# Altium schematic sheet Inverter.SchDoc
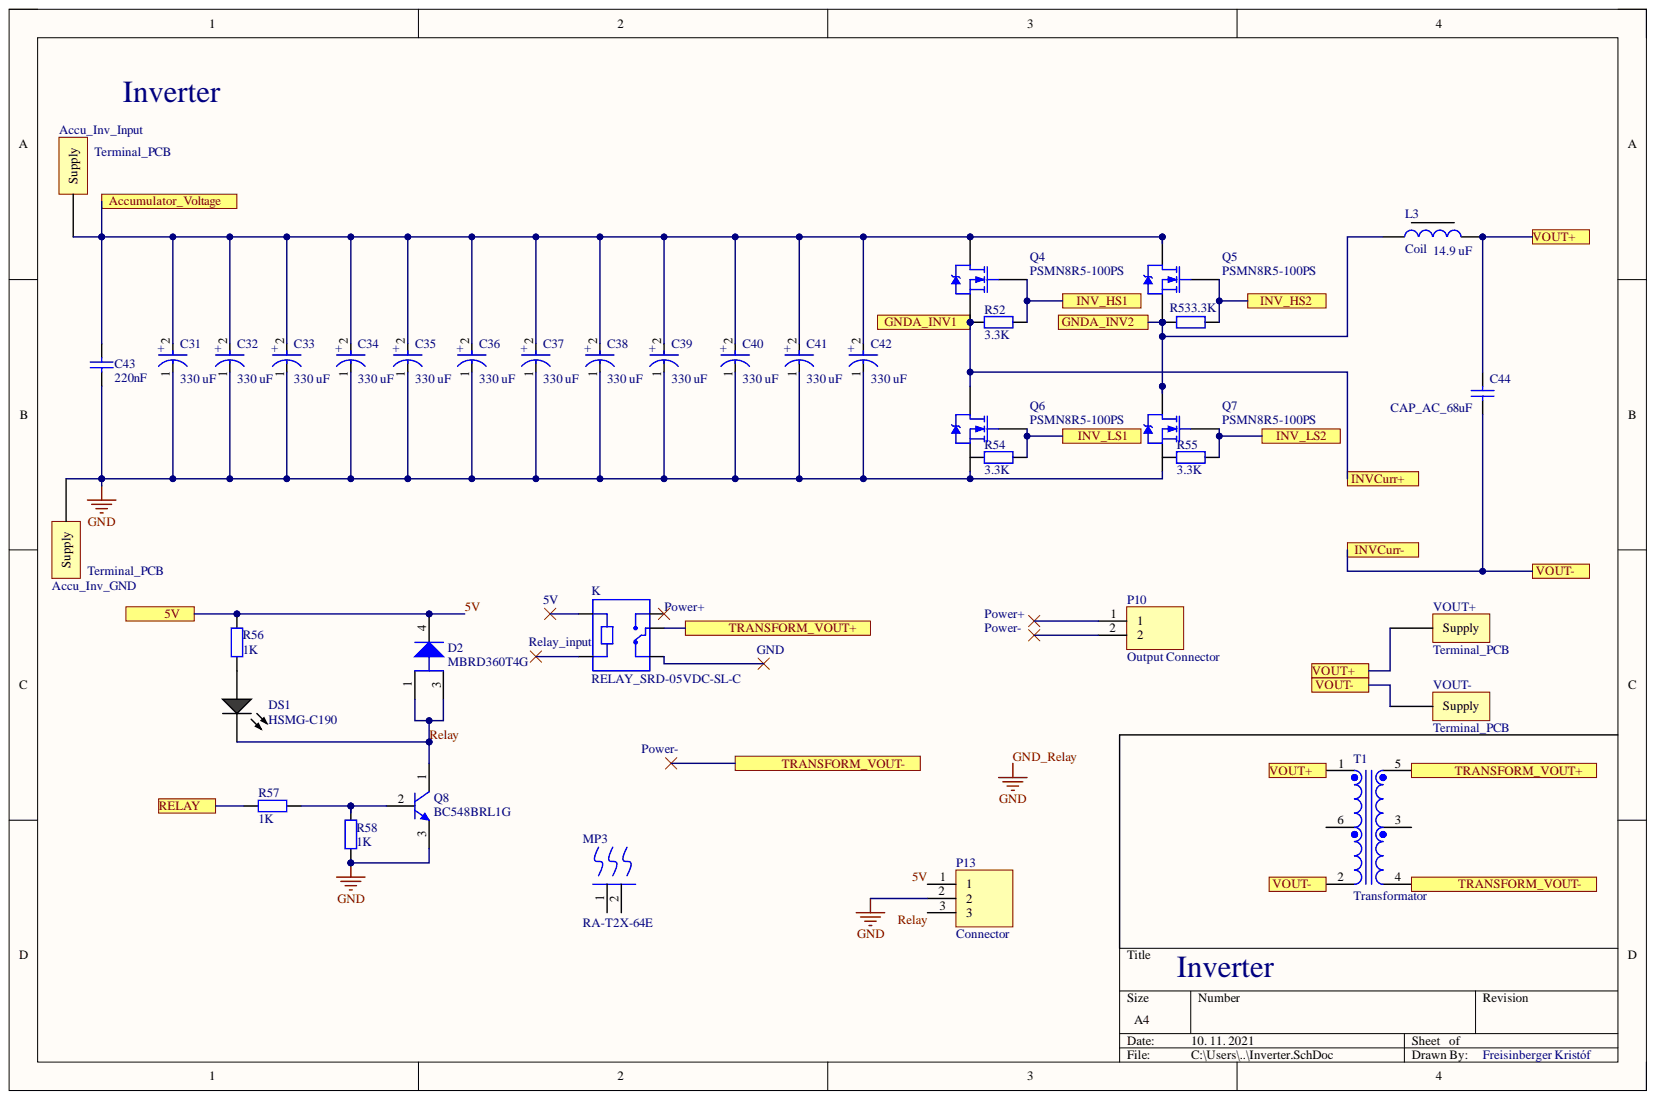
[diagram p0: Inverter.SchDoc]

## Parts
Accu_Inv_GND  Terminal_PCB  [Terminal_PCB_7798]
Accu_Inv_Input  Terminal_PCB  [Terminal_PCB_7798]
C31  330 uF  [FP-LXW18X25-MFG]
C32  330 uF  [FP-LXW18X25-MFG]
C33  330 uF  [FP-LXW18X25-MFG]
C34  330 uF  [FP-LXW18X25-MFG]
C35  330 uF  [FP-LXW18X25-MFG]
C36  330 uF  [FP-LXW18X25-MFG]
C37  330 uF  [FP-LXW18X25-MFG]
C38  330 uF  [FP-LXW18X25-MFG]
C39  330 uF  [FP-LXW18X25-MFG]
C40  330 uF  [FP-LXW18X25-MFG]
C41  330 uF  [FP-LXW18X25-MFG]
C42  330 uF  [FP-LXW18X25-MFG]
C43  220nF  [FP_0805_CAP_085]
C44  CAP_AC_68uF  [Capacitor_AC_68_uF]
D2  MBRD360T4G  [ONSC-DPAK-3-369C-01_V]
DS1  HSMG-C190  [AVAG-HSMX-C190_V]
K  RELAY_SRD-05VDC-SL-C  [FP_CONN3_2MM_90]
L3  Coil  value 14.9 uF  [Tekercs_E65_FERRITE]
MP3  RA-T2X-64E  [FP-RA-T2X-64E-MFG]
P10  Output Connector  [FP_CONN2_TAP_254MM]
P13  Connector  [FP_CONN3_TAP_254MM]
Q4  PSMN8R5-100PS  [FP-TO-220AB-MFG]
Q5  PSMN8R5-100PS  [FP-TO-220AB-MFG]
Q6  PSMN8R5-100PS  [FP-TO-220AB-MFG]
Q7  PSMN8R5-100PS  [FP-TO-220AB-MFG]
Q8  BC548BRL1G  [ONSC-TO-92-3-29-11_AM_BL]
R52  3.3K  [FP_0603_RES]
R53  3.3K  [FP_0603_RES]
R54  3.3K  [FP_0603_RES]
R55  3.3K  [FP_0603_RES]
R56  1K  [FP_0603_RES]
R57  1K  [FP_0603_RES]
R58  1K  [FP_0603_RES]
T1  Transformator  [TDK-ALT3232_V]
VOUT+  Terminal_PCB  [Terminal_PCB_7798]
VOUT-  Terminal_PCB  [Terminal_PCB_7798]

## Ports
5V, Accumulator_Voltage, GNDA_INV1, GNDA_INV2, INV_HS1, INV_HS2, INV_LS1, INV_LS2, INVCurr+, INVCurr-, RELAY, TRANSFORM_VOUT+, TRANSFORM_VOUT-, VOUT+, VOUT-

## Nets
5V: D2.4 P13.1 R56.2 ; port 5V
Accumulator_Voltage: Accu_Inv_Input.1 C31.2 C32.2 C33.2 C34.2 C35.2 C36.2 C37.2 C38.2 C39.2 C40.2 C41.2 C42.2 C43.2 Q4.2 Q5.2 ; port Accumulator_Voltage
GND_Relay: Accu_Inv_GND.1 C31.1 C32.1 C33.1 C34.1 C35.1 C36.1 C37.1 C38.1 C39.1 C40.1 C41.1 C42.1 C43.1 P13.2 Q6.3 Q7.3 Q8.3 R58.1
GNDA_INV1: Q4.3 Q6.2 R52.1 ; port GNDA_INV1
GNDA_INV2: L3.1 Q5.3 Q7.2 R53.1 ; port GNDA_INV2
INV_HS1: Q4.1 R52.2 ; port INV_HS1
INV_HS2: Q5.1 R53.2 ; port INV_HS2
INV_LS1: Q6.1 R54.2 ; port INV_LS1
INV_LS2: Q7.1 R55.2 ; port INV_LS2
RELAY: R57.1 ; port RELAY
Relay: D2.1 D2.3 DS1.2 P13.3 Q8.1
NetC44_1: C44.1 T1.2 VOUT-.1
NetC44_2: C44.2 L3.2 T1.1 VOUT+.1
NetDS1_1: DS1.1 R56.1
NetK_COM: K.COM T1.5
NetQ8_2: Q8.2 R57.2 R58.2
NetT1_4: T1.4

Not connected: K A1 A2 NC NO; MP3 1 2; P10 1 2; R54 1; R55 1; T1 3 6

## Library data of the parts
C31-C42 = CMP-35376-000008-1: CAP ALUM 47UF 20% 500V THRUHOLE  500LXW47MEFR18X25; Component Kind: Standard; Library Name: Altium Content Vault
C43 = CAP: Capacitor; Component Kind: Standard; Value: 220nF; Library Name: Napelem_Inverter.SchLib
C44 = CAP_AC_68uF: Cap Film 68uF 100V PET 10% (31.5 X 22 X 36.5mm) Radial 27.5mm 125C Bulk; Manufacturer: TDK EPCOS; Manufacturer Part Number: B32524Q1686K000; Dielectric Material: Polyester; Lead Diameter: 0.8mm; Capacitance: 68uF; Case/Package: Radial; Category: Film Capacitors; Component Kind: Standard; ComponentLink1Description: http://www.farnell.com/datasheets/1955213.pdf?src-supplier=Farnell; ComponentLink1URL: http://www.farnell.com/datasheets/1955213.pdf?src-supplier=Farnell; ComponentLink2Description: http://components.arrow.com/part/dataview/0323_01924821?src-supplier=Arrow+Europe; ComponentLink2URL: http://components.arrow.com/part/dataview/0323_01924821?src-supplier=Arrow+Europe; ComponentLink3Description: https://www.tdk-electronics.tdk.com/inf/20/20/db/fc_2009/B32520_529.pdf?src-supplier=Digi-Key; ComponentLink3URL: https://www.tdk-electronics.tdk.com/inf/20/20/db/fc_2009/B32520_529.pdf?src-supplier=Digi-Key; ComponentLink4Description: http://www.farnell.com/datasheets/1955213.pdf?src-supplier=Element14; ComponentLink4URL: http://www.farnell.com/datasheets/1955213.pdf?src-supplier=Element14; Depth: 22mm; Dielectric: PET; Lead Pitch: 27.5mm; Length: 31.5mm; Max Operating Temperature: 125°C; Min Operating Temperature: -55°C; Packaging: Bulk; Pricing 1: 1=16,57000, 10=15,74200, 50=13,25680, 100=12,42830, 500=11,01972, 1000=10,35688 (USD); REACH SVHC: No SVHC; RoHS: Yes; RoHS Compliant: Yes; Stock 1: 15; Supplier 1: Digi-Key; Tolerance: 10%; Voltage Rating: 100V; Voltage Rating (DC): 100V; Library Name: Napelem_Inverter.SchLib
D2 = CMP-1055-00192-1: SWITCHMODE(TM) Power Rectifier, 3-Pin DPAK, Pb-Free, Tape and Reel; Manufacturer: On Semiconductor; Configuration: Single; IFSM Max (A): 75; Component Kind: Standard; ComponentLink1Description: Manufacturer URL; ComponentLink1URL: http://www.onsemi.com/; ComponentLink2Description: Datasheet; ComponentLink2URL: http://www.onsemi.com/pub_link/Collateral/MBRD320-D.PDF; ComponentLink3Description: Package Specification; ComponentLink3URL: http://www.onsemi.cn/pub_link/Collateral/369C.PDF; DatasheetVersion: Rev. 8; Library Name: Altium Content Vault; Mounting Technology: Surface Mount; PackageDescription: 3-Pin Flange Mount, Body 6.54 x 6.095 mm, Pitch 2.29 mm; PackageReference: DPAK-3-369C-01; PackageVersion: Rev. E, 02/2014; Packing: Tape and Reel; PartNumber: MBRD360T4G; RoHS: TRUE
DS1 = LED_G_CMP-1744-00002-1_1: Chip LED, Green, 2.2 V, -40 to 85 degC, 2-Pin SMD, RoHS, Tape and Reel; Access Time: 3500 ns; Case\Package: SOIC; Clock Frequency: 100 KHz; Density: 32 kb; Frequency: 400 KHz; Interface: Serial; Max Supply Voltage: 5.5 V; Min Supply Voltage: 1.7 V; Nominal Supply Current: 2 mA; Component Kind: Standard; ComponentLink1Description: Manufacturer URL; ComponentLink1URL: http://www.avagotech.com/; ComponentLink2Description: Datasheet; ComponentLink2URL: http://docs.avagotech.com/docs/AV02-0551EN; ComponentLink3Description: Package Specification; ComponentLink3URL: http://www.fairchildsemi.com/dwg/MA/MA03D.pdf; DatasheetVersion: Mar-12; Height: 1.5 mm; Length: 5 mm; Max Clock Frequency: 100 KHz; Max Operating Temperature: 85 degC; Min Operating Temperature: -40 degC; Mount: Surface Mount; Package Quantity: 3000; PackageDescription: 2-Pin Surface Mount Device, Body 1.6 x 0.8 mm, Height 0.9 mm; PackageReference: HSMX-C190; Packaging: Tape and Reel; Pins: 8; Radiation Hardening: No; REACH SVHC: No SVHC; RoHSCompliant: true; Width: 4 mm; Library Name: Napelem_Inverter.SchLib
K = RELAY_SRD-05VDC-SL-C: 5V Trigger Relay Module For Arduino And Raspberry Pi 5V Trigger Relay Module For Arduino And Raspberry Pi; MF: Songle Relay; Availability: Unavailable; Component Kind: Standard; MP: SRD-05VDC-SL-C; Package: None; Price: None; SnapEDA_Link: https://www.snapeda.com/parts/SRD-05VDC-SL-C/Songle%20Relay/view-part/1029484/?ref=snap; Library Name: Napelem_Inverter.SchLib
L3 = Tekercs: 14.9 uH inductor; Category: Inductor; Component Kind: Standard; Library Name: Napelem_Inverter.SchLib
MP3 = CMP-33535-000005-1: HEATSINK TO-218,TO-220,TO-247; Natural Thermal Resistance: 3.1°C/W; Shape: Fins; Thermal Resistance @ Forced Air Flow (100 LFM): 1°C/W; Case/Package: TO-220; Color: Black; Component Kind: Standard; Height: 63.5mm; Height Off Base: 0.984inch; Length: 42mm; Library Name: Altium Content Vault; Material: Aluminium; Mount: Bolt; Package Quantity: 10; Packaging: Bulk; Type: Passive; Weight: 63g; Width: 25mm
P10 = Connector_2pin: 2pin connector; Component Kind: Standard; Library Name: Napelem_Inverter.SchLib
P13 = Connector_3pin: 3pin connector; Component Kind: Standard; Library Name: Napelem_Inverter.SchLib
Q4-Q7 = NMOS-2: N-channel 100 V 8.5 mΩ standard level MOSFET in TO220; Note: Pin 2 is electrically connected to pin 4.; Component Kind: Standard; ComponentLink1Description: Manufacturer Link; ComponentLink1URL: http://www.irf.com/; DatasheetVersion: PD-9.814; LatestRevisionDate: 17-Jul-2002; LatestRevisionNote: Re-released for DXP Platform.; Library Name: Miscellaneous Devices.IntLib; PackageDescription: TO, Thru-Hole, Vertical, Heatsink Mounted; 3 In-Line Leads; Pitch 2.54 mm; PackageReference: TO-220AB; PackageVersion: Feb-1997; Published: 8-Jun-2000; Simulation: IRF1010; Publisher: Altium Limited
Q8 = CMP-1048-01603-1: Amplifier Transistor, NPN Silicon, 3-Pin TO-92, Pb-Free, Tape and Reel; Manufacturer: On Semiconductor; fT Min (MHz): 150; IC Continuous (A): 0.1; Component Kind: Standard; ComponentLink1Description: Manufacturer URL; ComponentLink1URL: http://www.onsemi.com/; ComponentLink2Description: Datasheet; ComponentLink2URL: http://www.onsemi.com/pub_link/Collateral/BC546-D.PDF; ComponentLink3Description: Package Specification; ComponentLink3URL: http://www.onsemi.com/pub_link/Collateral/MAC97-D.PDF; DatasheetVersion: Rev. 7; Library Name: Altium Content Vault; Mounting Technology: Through Hole; PackageDescription: 3-Pin Transistor Outline, Body 4.825 x 3.685 mm, Pitch 1.27 mm; PackageReference: TO-92-3-29-11_AM_BL; PackageVersion: Rev. AM; Packing: Tape and Reel; PartNumber: BC548BRL1G; Polarity: NPN; RoHS: TRUE
R52-R58 = RES: Resistor; Component Kind: Standard; Library Name: Napelem_Inverter.SchLib
  R52-R55: Value: 3.3K
  R56-R58: Value: 1K
T1 = CMP-2000-05771-1: Pulse Transformer for LAN Interface, 2.5 dB, -40 to 85 degC, 6-Pin SMD, RoHS, Tape and Reel; Manufacturer: TDK; Insertion Loss: 2.5dB; Maximum Temperature: +85°C; Minimum Temperature: -40°C; Number of Output Ports: 1; Component Kind: Standard; Datasheet URL: https://product.tdk.com/info/en/catalog/datasheets/trans_alt_en.pdf; Datasheet Version: 05/2016; Depth: 2.9mm; Dimensions: 3.2x3.2x2.9mm; Length: 3.2mm; Library Name: Altium Content Vault; Manufacturer URL: http://www.tdk.com/; Mounting Technology: SurfaceMount; Mounting Type: SurfaceMount; Package Description: 6-Pin Surface Mount Device, Body 3.2 x 3.2 mm; Package Reference: ALT3232; Width: 3.2mm
Accu_Inv_GND Accu_Inv_Input VOUT+ VOUT- = Terminal_PCB: Component Kind: Standard; Library Name: Napelem_Inverter.SchLib
